# Altium schematic sheet DCTCXO.SchDoc
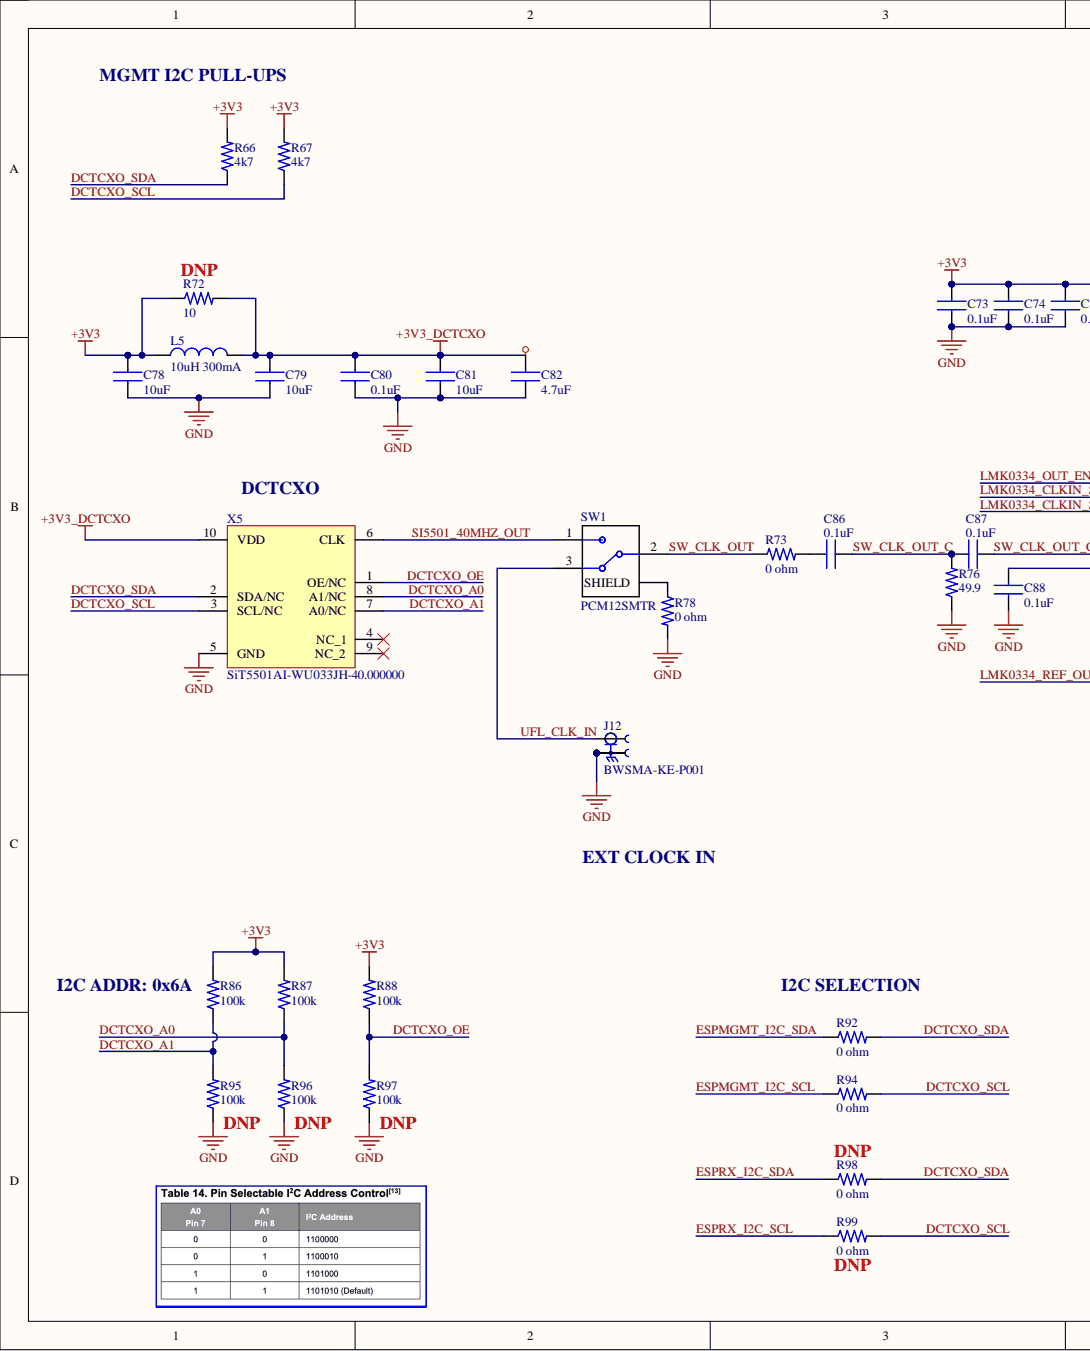
[diagram p0: DCTCXO.SchDoc, left]
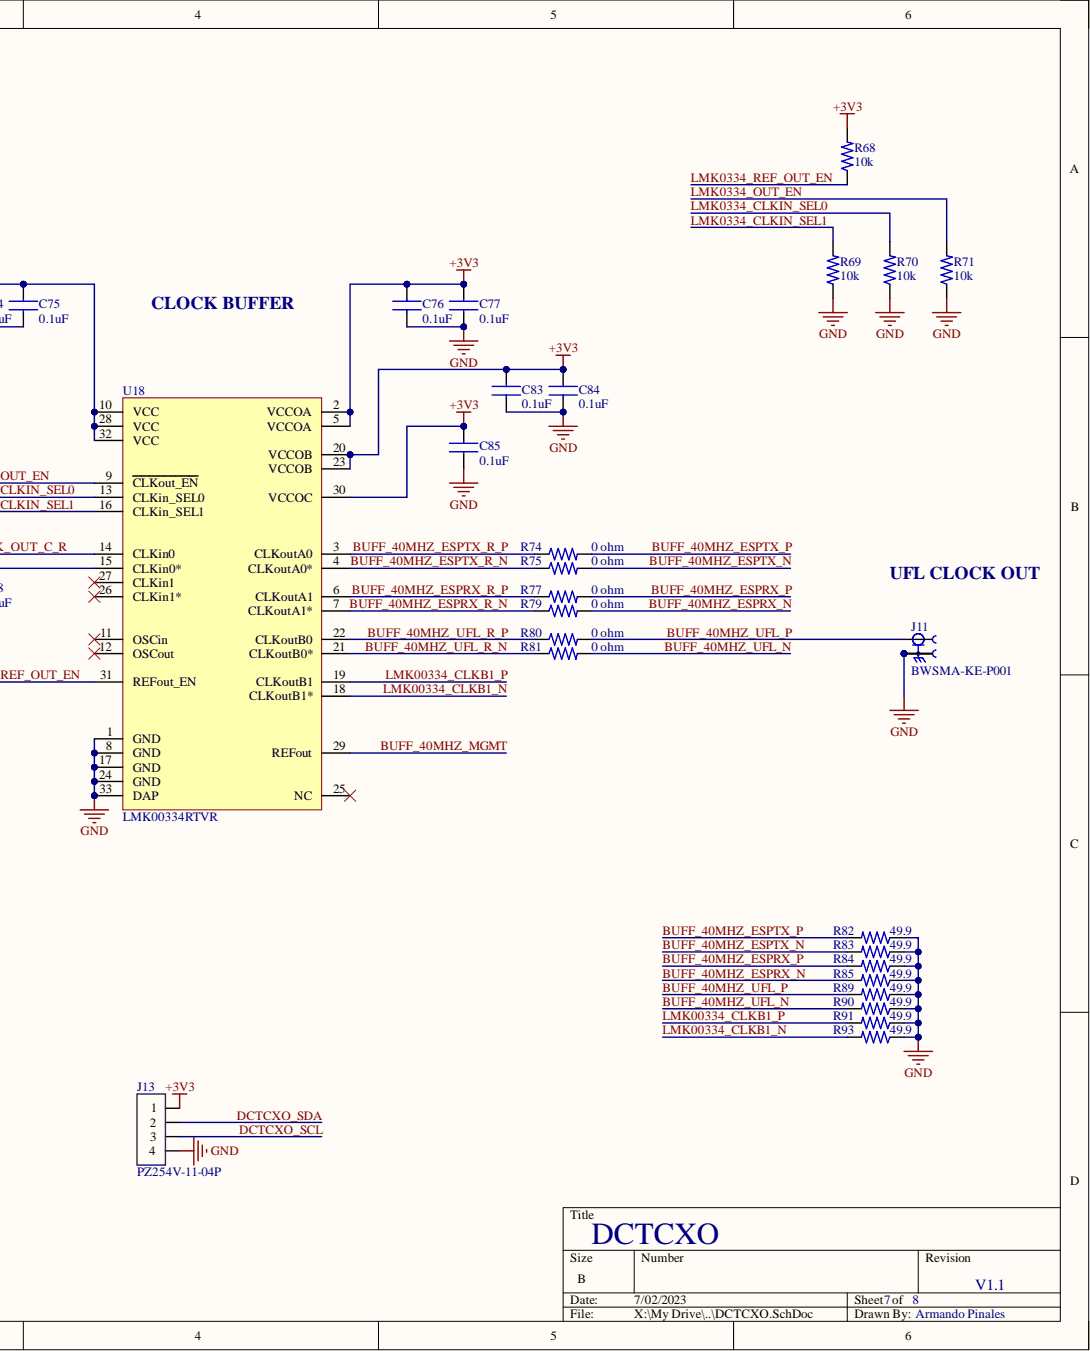
[diagram p1: DCTCXO.SchDoc, right]

## Parts
C73  0.1uF  [CAP_0402]
C74  0.1uF  [CAP_0402]
C75  0.1uF  [CAP_0402]
C76  0.1uF  [CAP_0402]
C77  0.1uF  [CAP_0402]
C78  10uF  [CAP_0402]
C79  10uF  [CAP_0402]
C80  0.1uF  [CAP_0402]
C81  10uF  [CAP_0402]
C82  4.7uF  [CAP_0402]
C83  0.1uF  [CAP_0402]
C84  0.1uF  [CAP_0402]
C85  0.1uF  [CAP_0402]
C86  0.1uF  [CAP_0402]
C87  0.1uF  [CAP_0402]
C88  0.1uF  [CAP_0402]
J11  BWSMA-KE-P001  [CONN_BWSMA-KE-P001]
J12  BWSMA-KE-P001  [CONN_BWSMA-KE-P001]
J13  PZ254V-11-04P  [CONN_4_PIN_M_100MIL]
L5  10uH 300mA  [L_490-LQM18DN100M70LCT-ND]
R66  4k7  [RES_0402]
R67  4k7  [RES_0402]
R68  10k  [RES_0402]
R69  10k  [RES_0402]
R70  10k  [RES_0402]
R71  10k  [RES_0402]
R72  10  [RES_0402]  (no BOM)
R73  0 ohm  [RES_0402]
R74  0 ohm  [RES_0402]
R75  0 ohm  [RES_0402]
R76  49.9  [RES_0402]
R77  0 ohm  [RES_0402]
R78  0 ohm  [RES_0402]
R79  0 ohm  [RES_0402]
R80  0 ohm  [RES_0402]
R81  0 ohm  [RES_0402]
R82  49.9  [RES_0402]
R83  49.9  [RES_0402]
R84  49.9  [RES_0402]
R85  49.9  [RES_0402]
R86  100k  [RES_0402]
R87  100k  [RES_0402]
R88  100k  [RES_0402]
R89  49.9  [RES_0402]
R90  49.9  [RES_0402]
R91  49.9  [RES_0402]
R92  0 ohm  [RES_0402]
R93  49.9  [RES_0402]
R94  0 ohm  [RES_0402]
R95  100k  [RES_0402]  (no BOM)
R96  100k  [RES_0402]  (no BOM)
R97  100k  [RES_0402]  (no BOM)
R98  0 ohm  [RES_0402]  (no BOM)
R99  0 ohm  [RES_0402]  (no BOM)
SW1  PCM12SMTR  [SW_PCM12SMTR]
U18  LMK00334RTVR  [IC_LMK00334RTVR]
X5  SiT5501AI-WU033JH-40.000000  [XTAL_SiT5501_70x50]

## Nets
+3V3: C73.2 C74.2 C75.2 C76.2 C77.2 C78.2 C83.2 C84.2 C85.2 J13.1 L5.1 R66.2 R67.2 R68.2 R72.1 R86.2 R87.2 R88.2 U18.2(VCCOA) U18.5(VCCOA) U18.10(VCC) U18.20(VCCOB) U18.23(VCCOB) U18.28(VCC) U18.30(VCCOC) U18.32(VCC)
+3V3_DCTCXO: C79.2 C80.2 C81.2 C82.2 L5.2 R72.2 X5.10(VDD)
GND: C73.1 C74.1 C75.1 C76.1 C77.1 C78.1 C79.1 C80.1 C81.1 C82.1 C83.1 C84.1 C85.1 C88.2 J11.2 J11.3 J11.4 J11.5 J12.2 J12.3 J12.4 J12.5 J13.4 R69.1 R70.1 R71.1 R76.1 R78.2 R82.1 R83.1 R84.1 R85.1 R89.1 R90.1 R91.1 R93.1 R95.1 R96.1 R97.1 U18.1(GND) U18.8(GND) U18.17(GND) U18.24(GND) U18.33(DAP) X5.5(GND)
BUFF_40MHZ_ESPRX_N: R79.2 R85.2
BUFF_40MHZ_ESPRX_P: R77.2 R84.2
BUFF_40MHZ_ESPRX_R_N: R79.1 U18.7(CLKoutA1*)
BUFF_40MHZ_ESPRX_R_P: R77.1 U18.6(CLKoutA1)
BUFF_40MHZ_ESPTX_N: R75.2 R83.2
BUFF_40MHZ_ESPTX_P: R74.2 R82.2
BUFF_40MHZ_ESPTX_R_N: R75.1 U18.4(CLKoutA0*)
BUFF_40MHZ_ESPTX_R_P: R74.1 U18.3(CLKoutA0)
BUFF_40MHZ_MGMT: U18.29(REFout)
BUFF_40MHZ_UFL_N: R81.2 R90.2
BUFF_40MHZ_UFL_P: J11.1 R80.2 R89.2
BUFF_40MHZ_UFL_R_N: R81.1 U18.21(CLKoutB0*)
BUFF_40MHZ_UFL_R_P: R80.1 U18.22(CLKoutB0)
DCTCXO_A0: R87.1 R96.2 X5.8(A1/NC)
DCTCXO_A1: R86.1 R95.2 X5.7(A0/NC)
DCTCXO_OE: R88.1 R97.2 X5.1(OE/NC)
DCTCXO_SCL: J13.3 R67.1 R94.2 R99.2 X5.3(SCL/NC)
DCTCXO_SDA: J13.2 R66.1 R92.2 R98.2 X5.2(SDA/NC)
ESPMGMT_I2C_SCL: R94.1
ESPMGMT_I2C_SDA: R92.1
ESPRX_I2C_SCL: R99.1
ESPRX_I2C_SDA: R98.1
LMK00334_CLKB1_N: R68.1 R69.2 R70.2 R71.2 R93.2 U18.9(CLKout_EN) U18.13(CLKin_SEL0) U18.16(CLKin_SEL1) U18.18(CLKoutB1*) U18.31(REFout_EN)  [also labelled LMK0334_CLKIN_SEL0, LMK0334_CLKIN_SEL1, LMK0334_OUT_EN, LMK0334_REF_OUT_EN]
LMK00334_CLKB1_P: R91.2 U18.19(CLKoutB1)
SI5501_40MHZ_OUT: SW1.1 X5.6(CLK)
SW_CLK_OUT: R73.1 SW1.2
SW_CLK_OUT_C: C86.1 C87.2 R76.2
SW_CLK_OUT_C_R: C87.1 U18.14(CLKin0)
UFL_CLK_IN: J12.1 SW1.3
NetC86_2: C86.2 R73.2
NetC88_1: C88.1 U18.15(CLKin0*)
NetR78_1: R78.1 SW1.S4(SHIELD)

Not connected: U18 11(OSCin) 12(OSCout) 25(NC) 26(CLKin1*) 27(CLKin1); X5 4(NC_1) 9(NC_2)

## Library data of the parts
C73-C77 C80 C83-C88 = CAP_GCM155R71C104KA55J: Cap Ceramic 0.1uF 16V X7R 10% SMD 0402 125C Paper T/R; Manufacturer: Murata; Capacitance: 100nF; Case Code (Imperial): 0402; Case Code (Metric): 1005; Case/Package: 0402; Category: Ceramic Capacitors; Component Kind: Standard; ComponentLink1Description: https://datasheet.ciiva.com/11618/product-11618848.pdf?src-supplier=Avnet; ComponentLink1URL: https://datasheet.ciiva.com/11618/product-11618848.pdf?src-supplier=Avnet; ComponentLink2Description: https://datasheet.ciiva.com/16440/gcm155r71c104ka55j-16440393.pdf?src-supplier=Future+Electronics; ComponentLink2URL: https://datasheet.ciiva.com/16440/gcm155r71c104ka55j-16440393.pdf?src-supplier=Future+Electronics; ComponentLink3Description: https://datasheet.ciiva.com/29542/product-29542474.pdf?src-supplier=Digi-Key; ComponentLink3URL: https://datasheet.ciiva.com/29542/product-29542474.pdf?src-supplier=Digi-Key; ComponentLink4Description: https://datasheet.ciiva.com/29542/product-29542475.pdf?src-supplier=Digi-Key; ComponentLink4URL: https://datasheet.ciiva.com/29542/product-29542475.pdf?src-supplier=Digi-Key; Construction: Flat; Depth: 0.5mm; Dielectric: X7R; Height: 0.5mm; Lead Free: Lead Free; Length: 1mm; Manufacturer Part Number: GCM155R71C104KA55J; Max Operating Temperature: 125°C; Min Operating Temperature: -55°C; Mount: Surface Mount; Package Quantity: 1; Packaging: Tape and Reel; Pricing 1: 1=0.10000, 10=0.03500, 50=0.01920, 100=0.01560, 500=0.01116, 1000=0.00877, 2500=0.00798, 5000=0.00734 (USD); Radiation Hardening: No; Ratings: AEC-Q200; RoHS: Yes; Series: GCM; Stock 1: 10738061; Supplier 1: Digi-Key; Supplier Part Number 1: 490-7998-1-ND; Termination: SMD/SMT; Thickness: 0.022inch; Tolerance: 10%; Voltage Rating: 16V; Voltage Rating (DC): 16V; Width: 0.5mm; Library Name: LotusSound.IntLib
C78 C79 C81 = CAP_CL05A106MQ5NUNC: Cap Ceramic 10uF 6.3V X5R 20% SMD 0402 85°C Paper T/R; Manufacturer: Samsung; Capacitance: 10uF; Case Code (Imperial): 0402; Case Code (Metric): 1005; Case/Package: 0402; Category: Ceramic Capacitors; Component Kind: Standard; ComponentLink1Description: https://datasheet.ciiva.com/16437/cl05a106mq5nunc-16437356.pdf?src-supplier=Future+Electronics; ComponentLink1URL: https://datasheet.ciiva.com/16437/cl05a106mq5nunc-16437356.pdf?src-supplier=Future+Electronics; ComponentLink2Description: https://datasheet.ciiva.com/26877/getdatasheetpartid-355289-26877841.pdf?src-supplier=Verical; ComponentLink2URL: https://datasheet.ciiva.com/26877/getdatasheetpartid-355289-26877841.pdf?src-supplier=Verical; Construction: Flat; Depth: 0.5mm; Dielectric: X5R; Height: 0.5mm; Length: 1mm; Manufacturer Part Number: CL05A106MQ5NUNC; Max Operating Temperature: 85°C; Min Operating Temperature: -55°C; Mount: Surface Mount; Package Quantity: 1; Packaging: Tape and Reel; Pricing 1: 1=0.27000, 10=0.18400, 50=0.11020, 100=0.09300, 500=0.06362, 1000=0.05629, 2500=0.05384, 5000=0.04894 (USD); Radiation Hardening: No; RoHS: Yes; Series: CL; Stock 1: 3345553; Supplier 1: Digi-Key; Supplier Part Number 1: 1276-1451-1-ND; Thickness: 0.028inch; Tolerance: 20%; Voltage Rating: 6.3V; Width: 0.02inch; Library Name: LotusSound.IntLib
C82 = CAP_LMK105BBJ475MVLF: Multilayer Ceramic Capacitors MLCC - SMD/SMT 4.7uF 10V X5R +/-20% 0402 Gen Purp; Manufacturer: Taiyo Yuden; Tolerance: 20%; Capacitance: 4.7uF; Case Code (Imperial): 0402; Case Code (Metric): 1005; Case/Package: 0402; Category: Ceramic Capacitors; Component Kind: Standard; ComponentLink1Description: https://datasheet.ciiva.com/24462/423lmk105bbj475mvlf-24462535.pdf?src-supplier=Onlinecomponents.com; ComponentLink1URL: https://datasheet.ciiva.com/24462/423lmk105bbj475mvlf-24462535.pdf?src-supplier=Onlinecomponents.com; ComponentLink2Description: https://datasheet.ciiva.com/18697/mlcc-all-e-18697686.pdf?src-supplier=Digi-Key; ComponentLink2URL: https://datasheet.ciiva.com/18697/mlcc-all-e-18697686.pdf?src-supplier=Digi-Key; ComponentLink3Description: https://datasheet.ciiva.com/18697/mlcc-all-e-18697685.pdf?src-supplier=Digi-Key; ComponentLink3URL: https://datasheet.ciiva.com/18697/mlcc-all-e-18697685.pdf?src-supplier=Digi-Key; Dielectric: X5R; Halogen Free: Halogen Free; Height: 0.5mm; Length: 1mm; Manufacturer Part Number: LMK105BBJ475MVLF; Max Operating Temperature: 85°C; Min Operating Temperature: -55°C; Mount: Surface Mount; Package Quantity: 10000; Packaging: Tape and Reel; Pricing 1: 1=0.21000, 10=0.14400, 50=0.08640, 100=0.07300, 500=0.04994, 1000=0.04417, 2500=0.04225, 5000=0.03841 (USD); Resistor Type: Ceramic; RoHS: Yes; RoHS Compliant: Yes; Supplier 1: Digi-Key; Supplier Part Number 1: 587-3408-1-ND; Termination: SMD/SMT; Thickness: 0.022inch; Voltage Rating: 10V; Voltage Rating (DC): 10V; Weight: 2.3E-05oz; Width: 0.02inch; Library Name: LotusSound.IntLib
J11 J12 = CONN_BWSMA-KE-P001: 0-12.4GHz 50Ω 335V 直插偏脚; Manufacturer: BAT Wireless; Component Kind: Standard; Manufacturer Part Number: BWSMA-KE-P001; Pricing 1: 1=0.3453, 10=0.2721, 30=0.2355, 100=0.2037, 500=0.1957, 1000=0.1894 (USD); RoHS: No; Stock 1: 21382; Supplier 1: LCSC; Supplier Part Number 1: C496550; Library Name: LotusSound.IntLib
J13 = CONN_4_PIN_MALE_PZ254V-11-04P: 单排排针 间距2.54mm 4pin 直插; Manufacturer: XFCN; Component Kind: Standard; Manufacturer Part Number: PZ254V-11-04P; Pricing 1: 20=0.0196, 200=0.0157, 1000=0.0125, 2000=0.0112, 10000=0.01, 20000=0.0095 (USD); RoHS: No; Stock 1: 101260; Supplier 1: LCSC; Supplier Part Number 1: C2691448; Library Name: LotusSound.IntLib
L5 = L_LQM18DN100M70L: Inductor, 10Uh, 0.3A, Multilayer Rohs Compliant: Yes; Manufacturer: Murata; Category: Fixed Inductors; Component Kind: Standard; Manufacturer Part Number: LQM18DN100M70L; Pricing 1: 1=0.12000, 10=0.10500, 25=0.09280, 50=0.07900, 100=0.06970, 250=0.06388, 500=0.05808, 1000=0.04878 (USD); RoHS: Yes; Stock 1: 236207; Supplier 1: Digi-Key; Supplier Part Number 1: 490-LQM18DN100M70LCT-ND; Library Name: LotusSound.IntLib
R66 R67 = RES_RC0402JR-074K7L: Res General Purpose Thick Film 0402 4.7K Ohm 5% 1/16W ±100ppm/°C Molded SMD Paper T/R; Manufacturer: Yageo; Max Power Dissipation: 63mW; Power Rating: 62.5mW; Value: 4.7kR; Voltage Rating: 50V; Case Code (Imperial): 0402; Case Code (Metric): 1005; Case/Package: 0402; Category: Chip SMD Resistors; Component Kind: Standard; Construction: Rectangular; Depth: 0.5mm; Features: Moisture Resistant; Height: 0.016inch; Lead Free: Lead Free; Length: 0.039inch; Manufacturer Part Number: RC0402JR-074K7L; Max Operating Temperature: 155°C; Military Standard: Not; Min Operating Temperature: -55°C; Number of Terminations: 2; Package Quantity: 1; Packaging: Tape and Reel; Pricing 1: 1=0.10000, 10=0.01200, 100=0.00480, 1000=0.00214, 2500=0.00186, 5000=0.00154 (USD); Reach SVHC Compliant: Yes; Resistance Tolerance: 5%; Resistor Type: Thick Film; RoHS: Yes; RoHS Compliant: Yes; Series: RC; Stock 1: 26835683; Supplier 1: Digi-Key; Supplier Part Number 1: 311-4.7KJRCT-ND; Temperature Coefficient: 100ppm/°C; Termination: SMD/SMT; Tolerance: 5%; Width: 0.02inch; Library Name: LotusSound.IntLib
R68-R71 = RES_AC0402FR-0710KL: RES SMD 10K OHM 1% 1/16W 0402; Manufacturer: Yageo; Max Power Dissipation: 63mW; Power Rating: 62.5mW; Resistance: 10kR; Temperature Coefficient: 100ppm/°C; Voltage Rating: 50V; Case Code (Imperial): 0402; Case Code (Metric): 1005; Case/Package: 0402; Category: Chip SMD Resistors; Component Kind: Standard; ComponentLink1Description: https://datasheet.ciiva.com/12656/pyu-ac-51-rohs-l-2-12656634.pdf?src-supplier=Mouser; ComponentLink1URL: https://datasheet.ciiva.com/12656/pyu-ac-51-rohs-l-2-12656634.pdf?src-supplier=Mouser; Construction: Rectangular; Depth: 0.5mm; Features: Moisture Resistant,Automotive AEC-Q200; Height: 0.015inch; Lead Free: Lead Free; Length: 0.039inch; Manufacturer Part Number: AC0402FR-0710KL; Max Operating Temperature: 155°C; Military Standard: Not; Min Operating Temperature: -55°C; Number of Terminations: 2; Packaging: Tape and Reel; Pricing 1: 1=0.10000, 10=0.02200, 100=0.00900, 1000=0.00402, 2500=0.00349, 5000=0.00288 (USD); Resistor Type: Thick Film; RoHS: Yes; RoHS Compliant: Yes; Series: AC; Size Code: 0402; Stock 1: 2860; Supplier 1: Digi-Key; Supplier Part Number 1: YAG3436CT-ND; Termination: SMD/SMT; Tolerance: 1%; Width: 0.02inch; Library Name: LotusSound.IntLib
R72 = RES_RC0402FR-0710RL: Res General Purpose Thick Film 0402 10 Ohm 1% 1/16W ±200ppm/°C Molded SMD Paper T/R; Manufacturer: Yageo; Max Power Dissipation: 63mW; Power Rating: 62.5mW; Value: 10R; Voltage Rating: 50V; Case Code (Imperial): 0402; Case Code (Metric): 1005; Case/Package: 0402; Category: Chip SMD Resistors; ComponentLink1Description: https://datasheet.ciiva.com/46394/1018594-46394954.pdf?src-supplier=Element14; ComponentLink1URL: https://datasheet.ciiva.com/46394/1018594-46394954.pdf?src-supplier=Element14; ComponentLink2Description: https://datasheet.ciiva.com/7849/1018594-7849818.pdf?src-supplier=Element14; ComponentLink2URL: https://datasheet.ciiva.com/7849/1018594-7849818.pdf?src-supplier=Element14; ComponentLink3Description: https://datasheet.ciiva.com/7849/1018594-7849819.pdf?src-supplier=Element14; ComponentLink3URL: https://datasheet.ciiva.com/7849/1018594-7849819.pdf?src-supplier=Element14; ComponentLink4Description: https://datasheet.ciiva.com/15189/getdatasheetpartid-323697-15189339.pdf?src-supplier=Verical; ComponentLink4URL: https://datasheet.ciiva.com/15189/getdatasheetpartid-323697-15189339.pdf?src-supplier=Verical; Construction: Rectangular; Depth: 0.5mm; Features: Moisture Resistant; Height: 0.32mm; Lead Free: Lead Free; Length: 1mm; Manufacturer Part Number: RC0402FR-0710RL; Max Operating Temperature: 155°C; Military Standard: Not; Min Operating Temperature: -55°C; Number of Terminations: 2; Packaging: Tape and Reel; Pricing 1: 1=0.10000, 10=0.02200, 100=0.00880, 1000=0.00396, 2500=0.00344, 5000=0.00284 (USD); Reach SVHC Compliant: Yes; Resistance Tolerance: 1%; Resistor Type: Thick Film; RoHS: Yes; RoHS Compliant: Yes; Series: RC; Stock 1: 6576941; Supplier 1: Digi-Key; Supplier Part Number 1: 311-10.0LRCT-ND; Technology: THICK FILM; Temperature Coefficient: 200ppm/°C; Termination: SMD/SMT; Tolerance: 1%; Working Voltage: 50V; Library Name: LotusSound.IntLib
R73-R75 R77-R81 R92 R94 R98 R99 = RES_RC0402JR-070RL: RES SMD 0 OHM JUMPER 1/16W 0402; Manufacturer: Yageo; Max Power Dissipation: 63mW; Power Rating: 62.5mW; Resistance Tolerance: 5%; Temperature Coefficient: 200ppm/°C; Value: 0mR; Voltage Rating: 50V; Case Code (Imperial): 0402; Case Code (Metric): 1005; Case/Package: 0402; Category: Chip SMD Resistors; ComponentLink1Description: https://datasheet.ciiva.com/2452/pyu-rc0402-51-rohs-l-6-2452608.pdf?src-supplier=Digi-Key; ComponentLink1URL: https://datasheet.ciiva.com/2452/pyu-rc0402-51-rohs-l-6-2452608.pdf?src-supplier=Digi-Key; ComponentLink2Description: https://datasheet.ciiva.com/7983/1018594-7983535.pdf?src-supplier=Element14; ComponentLink2URL: https://datasheet.ciiva.com/7983/1018594-7983535.pdf?src-supplier=Element14; ComponentLink3Description: https://datasheet.ciiva.com/22747/1018594-22747515.pdf?src-supplier=Element14; ComponentLink3URL: https://datasheet.ciiva.com/22747/1018594-22747515.pdf?src-supplier=Element14; ComponentLink4Description: https://datasheet.ciiva.com/2452/pyu-r-int-thick-7-2452609.pdf?src-supplier=Digi-Key; ComponentLink4URL: https://datasheet.ciiva.com/2452/pyu-r-int-thick-7-2452609.pdf?src-supplier=Digi-Key; ComponentLink5Description: https://datasheet.ciiva.com/2452/pyu-r-int-thick-7-2452610.pdf?src-supplier=Digi-Key; ComponentLink5URL: https://datasheet.ciiva.com/2452/pyu-r-int-thick-7-2452610.pdf?src-supplier=Digi-Key; ComponentLink6Description: https://datasheet.ciiva.com/8896/pyu-rc0402-51-rohs-l-6-8896201.pdf?src-supplier=Avnet; ComponentLink6URL: https://datasheet.ciiva.com/8896/pyu-rc0402-51-rohs-l-6-8896201.pdf?src-supplier=Avnet; ComponentLink7Description: https://datasheet.ciiva.com/15189/getdatasheetpartid-326379-15189533.pdf?src-supplier=Verical; ComponentLink7URL: https://datasheet.ciiva.com/15189/getdatasheetpartid-326379-15189533.pdf?src-supplier=Verical; Construction: Rectangular; Contact Plating: Tin,Matte; Depth: 0.5mm; Features: Moisture Resistant; Height: 0.016inch; Lead Free: Lead Free; Length: 1mm; Manufacturer Part Number: RC0402JR-070RL; Max Operating Temperature: 155°C; Military Standard: Not; Min Operating Temperature: -55°C; Number of Terminations: 2; Package Quantity: 1; Packaging: Tape and Reel; Pricing 1: 1=0.10000, 10=0.01200, 25=0.00840, 50=0.00640, 100=0.00480, 250=0.00364, 500=0.00290, 1000=0.00214, 5000=0.00154 (USD); Radiation Hardening: No; Resistor Type: Thick Film; RoHS: Yes; RoHS Compliant: Yes; Series: RC; Stock 1: 33185339; Supplier 1: Digi-Key; Supplier Part Number 1: 311-0.0JRCT-ND; Technology: THICK FILM; Termination: SMD/SMT; Tolerance: 5%; Width: 0.02inch; Library Name: LotusSound.IntLib
  R73-R75 R77-R81 R92 R94: Component Kind: Standard
R76 R82-R85 R89-R91 R93 = RES_RC0402FR-0749R9L: Res General Purpose Thick Film 0402 49.9 Ohm 1% 1/16W ±100ppm/°C Molded SMD Paper T/R; Manufacturer: Yageo; Max Power Dissipation: 63mW; Power Rating: 62.5mW; Value: 49.9R; Voltage Rating: 50V; Case Code (Imperial): 0402; Case Code (Metric): 1005; Case/Package: 0402; Category: Chip SMD Resistors; Component Kind: Standard; ComponentLink1Description: https://datasheet.ciiva.com/7983/1018594-7983542.pdf?src-supplier=Element14; ComponentLink1URL: https://datasheet.ciiva.com/7983/1018594-7983542.pdf?src-supplier=Element14; ComponentLink2Description: https://datasheet.ciiva.com/22747/1018594-22747514.pdf?src-supplier=Element14; ComponentLink2URL: https://datasheet.ciiva.com/22747/1018594-22747514.pdf?src-supplier=Element14; ComponentLink3Description: https://datasheet.ciiva.com/2453/pyu-r-int-thick-7-2453356.pdf?src-supplier=Digi-Key; ComponentLink3URL: https://datasheet.ciiva.com/2453/pyu-r-int-thick-7-2453356.pdf?src-supplier=Digi-Key; ComponentLink4Description: https://datasheet.ciiva.com/2453/pyu-r-int-thick-7-2453357.pdf?src-supplier=Digi-Key; ComponentLink4URL: https://datasheet.ciiva.com/2453/pyu-r-int-thick-7-2453357.pdf?src-supplier=Digi-Key; ComponentLink5Description: https://datasheet.ciiva.com/2453/pyu-r-int-thick-7-2453355.pdf?src-supplier=Digi-Key; ComponentLink5URL: https://datasheet.ciiva.com/2453/pyu-r-int-thick-7-2453355.pdf?src-supplier=Digi-Key; ComponentLink6Description: https://datasheet.ciiva.com/16743/pyu-rc0402-51-rohs-l-6-r-16743942.pdf?src-supplier=Future+Electronics; ComponentLink6URL: https://datasheet.ciiva.com/16743/pyu-rc0402-51-rohs-l-6-r-16743942.pdf?src-supplier=Future+Electronics; Construction: Rectangular; Depth: 0.5mm; Features: Moisture Resistant; Height: 0.016inch; Lead Free: Lead Free; Length: 1mm; Manufacturer Part Number: RC0402FR-0749R9L; Max Operating Temperature: 155°C; Military Standard: Not; Min Operating Temperature: -55°C; Number of Terminations: 2; Package Quantity: 1; Packaging: Tape and Reel; Pins: 2; Pricing 1: 1=0.10000, 10=0.01400, 100=0.00580, 1000=0.00262, 2500=0.00228, 5000=0.00188 (USD); Reach SVHC Compliant: Yes; Resistance Tolerance: 1%; Resistor Type: Thick Film; RoHS: Yes; RoHS Compliant: Yes; Series: RC; Stock 1: 11146612; Supplier 1: Digi-Key; Supplier Part Number 1: 311-49.9LRCT-ND; Technology: THICK FILM; Temperature Coefficient: 100ppm/°C; Termination: SMD/SMT; Tolerance: 1%; Voltage Rating (DC): 50V; Width: 0.02inch; Working Voltage: 50V; Library Name: LotusSound.IntLib
R86-R88 R95-R97 = RES_CRCW0402100KFKED: Res Thick Film 0402 100K Ohm 1% 1/16W ±100ppm/°C Molded SMD Paper T/R; Manufacturer: Vishay; Max Power Dissipation: 63mW; Resistance: 100kR; Voltage Rating: 50V; Case Code (Imperial): 0402; Case Code (Metric): 1005; Case/Package: 0402; Category: Chip SMD Resistors; ComponentLink1Description: https://datasheet.ciiva.com/11810/0900766b80d98824-11810752.pdf?src-supplier=RSComponents; ComponentLink1URL: https://datasheet.ciiva.com/11810/0900766b80d98824-11810752.pdf?src-supplier=RSComponents; ComponentLink2Description: https://datasheet.ciiva.com/2463/dcrcwe3-2463064.pdf?src-supplier=Digi-Key; ComponentLink2URL: https://datasheet.ciiva.com/2463/dcrcwe3-2463064.pdf?src-supplier=Digi-Key; ComponentLink3Description: https://datasheet.ciiva.com/2463/dcrcwe3-2463065.pdf?src-supplier=Digi-Key; ComponentLink3URL: https://datasheet.ciiva.com/2463/dcrcwe3-2463065.pdf?src-supplier=Digi-Key; ComponentLink4Description: https://datasheet.ciiva.com/2463/dcrcwe3-2463066.pdf?src-supplier=Digi-Key; ComponentLink4URL: https://datasheet.ciiva.com/2463/dcrcwe3-2463066.pdf?src-supplier=Digi-Key; ComponentLink5Description: https://datasheet.ciiva.com/3255/dcrcwe3-3255947.pdf?src-supplier=Avnet; ComponentLink5URL: https://datasheet.ciiva.com/3255/dcrcwe3-3255947.pdf?src-supplier=Avnet; ComponentLink6Description: https://datasheet.ciiva.com/3255/dcrcwe3-3255948.pdf?src-supplier=Avnet; ComponentLink6URL: https://datasheet.ciiva.com/3255/dcrcwe3-3255948.pdf?src-supplier=Avnet; ComponentLink7Description: https://datasheet.ciiva.com/5546/dcrcwe3-109170-5546638.pdf?src-supplier=Mouser; ComponentLink7URL: https://datasheet.ciiva.com/5546/dcrcwe3-109170-5546638.pdf?src-supplier=Mouser; ComponentLink8Description: https://datasheet.ciiva.com/26316/fld-crcw-e3-data-en-26316630.pdf?src-supplier=Distrelec; ComponentLink8URL: https://datasheet.ciiva.com/26316/fld-crcw-e3-data-en-26316630.pdf?src-supplier=Distrelec; ComponentLink9Description: https://datasheet.ciiva.com/16941/dcrcwe3-16941129.pdf?src-supplier=Avnet+Europe; ComponentLink9URL: https://datasheet.ciiva.com/16941/dcrcwe3-16941129.pdf?src-supplier=Avnet+Europe; ComponentLink10Description: https://datasheet.ciiva.com/16208/dcrcwe3-16208508.pdf?src-supplier=Future+Electronics; ComponentLink10URL: https://datasheet.ciiva.com/16208/dcrcwe3-16208508.pdf?src-supplier=Future+Electronics; ComponentLink11Description: https://datasheet.ciiva.com/46441/1720486-46441598.pdf?src-supplier=Element14; ComponentLink11URL: https://datasheet.ciiva.com/46441/1720486-46441598.pdf?src-supplier=Element14; ComponentLink12Description: https://datasheet.ciiva.com/16776/70201119-16776281.pdf?src-supplier=Allied+Electronics; ComponentLink12URL: https://datasheet.ciiva.com/16776/70201119-16776281.pdf?src-supplier=Allied+Electronics; Construction: Rectangular; Contact Plating: Tin; Depth: 0.5mm; Features: Automotive AEC-Q200; Height: 0.35mm; Lead Free: Lead Free; Length: 1mm; Manufacturer Part Number: CRCW0402100KFKED; Max Operating Temperature: 155°C; Military Standard: Not; Min Operating Temperature: -55°C; Mount: Surface Mount; Number of Pins: 2; Number of Terminations: 2; Package Quantity: 10000; Packaging: Tape and Reel; Power Rating: 63mW; Pricing 1: 1=0.00600, 10000=0.00600 (USD); Radiation Hardening: No; REACH SVHC: Unknown; Resistance Tolerance: 1%; Resistor Type: Thick Film; RoHS: Yes; RoHS Compliant: Yes; Series: CRCW; Size Code: 0402; Stock 1: 3660000; Supplier 1: Newark; Supplier Part Number 1: 24W1923; Technology: THICK FILM; Temperature Coefficient: 100ppm/°C; Termination: SMD/SMT; Tolerance: 1%; Voltage Rating (DC): 50V; Weight: 2.3E-05oz; Width: 0.02inch; Library Name: LotusSound.IntLib
  R86-R88: Component Kind: Standard
SW1 = SW_PCM12SMTR: Slide Switch SPDT Surface Mount, Right Angle; MF: C&K; Component Kind: Standard; Digi-Key_Part_Number: 401-2016-1-ND; Digi-Key_Purchase_URL: https://www.digikey.com/product-detail/en/c-k/PCM12SMTR/401-2016-1-ND/1640125?utm_source=snapeda&utm_medium=aggregator&utm_campaign=symbol; MP: PCM12SMTR; Package: DO-201 C&amp;K Components; SnapEDA_Link: https://www.snapeda.com/parts/PCM12SMTR/C&K/view-part/229977/?ref=snap; Library Name: LotusSound.IntLib
U18 = IC_LMK00334RTVR: 4-output PCIe Gen1/Gen2/Gen3/Gen4 clock buffer and level translator 32-WQFN -40 to 85; Manufacturer: Texas Instruments; Clock Frequency: 400MHz; Input: Crystal; Max Duty Cycle: 55%; Max Supply Voltage: 3.45V; Min Supply Voltage: 3.15V; Number of Circuits: 1; Number of Outputs: 4; Operating Supply Current: 13.5mA; Operating Supply Voltage: 3.3V; PLL: No; Propagation Delay: 2700ps; Supply Current: 8.5mA; Category: Clock Buffers, Drivers; Component Kind: Standard; ComponentLink1Description: https://datasheet.ciiva.com/28657/1789733-28657440.pdf?src-supplier=Element14; ComponentLink1URL: https://datasheet.ciiva.com/28657/1789733-28657440.pdf?src-supplier=Element14; ComponentLink2Description: https://datasheet.ciiva.com/29661/lmk00334-29661008.pdf?src-supplier=Digi-Key; ComponentLink2URL: https://datasheet.ciiva.com/29661/lmk00334-29661008.pdf?src-supplier=Digi-Key; Frequency: 400MHz; Lead Free: Lead Free; Manufacturer Part Number: LMK00334RTVR; Max Operating Temperature: 85°C; Min Operating Temperature: -40°C; Mounting Technology: Surface Mount; Package Quantity: 1000; Packaging: Tape and Reel; Pins: 32; Pricing 1: 1=2.0539, 10=1.7676, 30=1.5894, 100=1.3841, 500=1.3014, 1000=1.2648 (USD); Radiation Hardening: No; Reach SVHC Compliant: No; RoHS: Yes; RoHS Compliant: Yes; Series: -; Stock 1: 1001; Supplier 1: LCSC; Supplier Part Number 1: C201644; Library Name: LotusSound.IntLib
X5 = XTAL_SIT5501AI-WUG33JT-10.000000: 1 MHz  60 MHz, Elite X Stratum 3E Precision Oscillator; Manufacturer_Name: SiTime; Geometry.Height: 2.2mm; Component Kind: Standard; Datasheet Link: https://www.sitime.com/support/resource-library/datasheets/sit5501-datasheet; Manufacturer_Part_Number: SiT5501AI-WU033JH-40.000000; Library Name: LotusSound.IntLib
